# Altium schematic sheet RAM.SchDoc
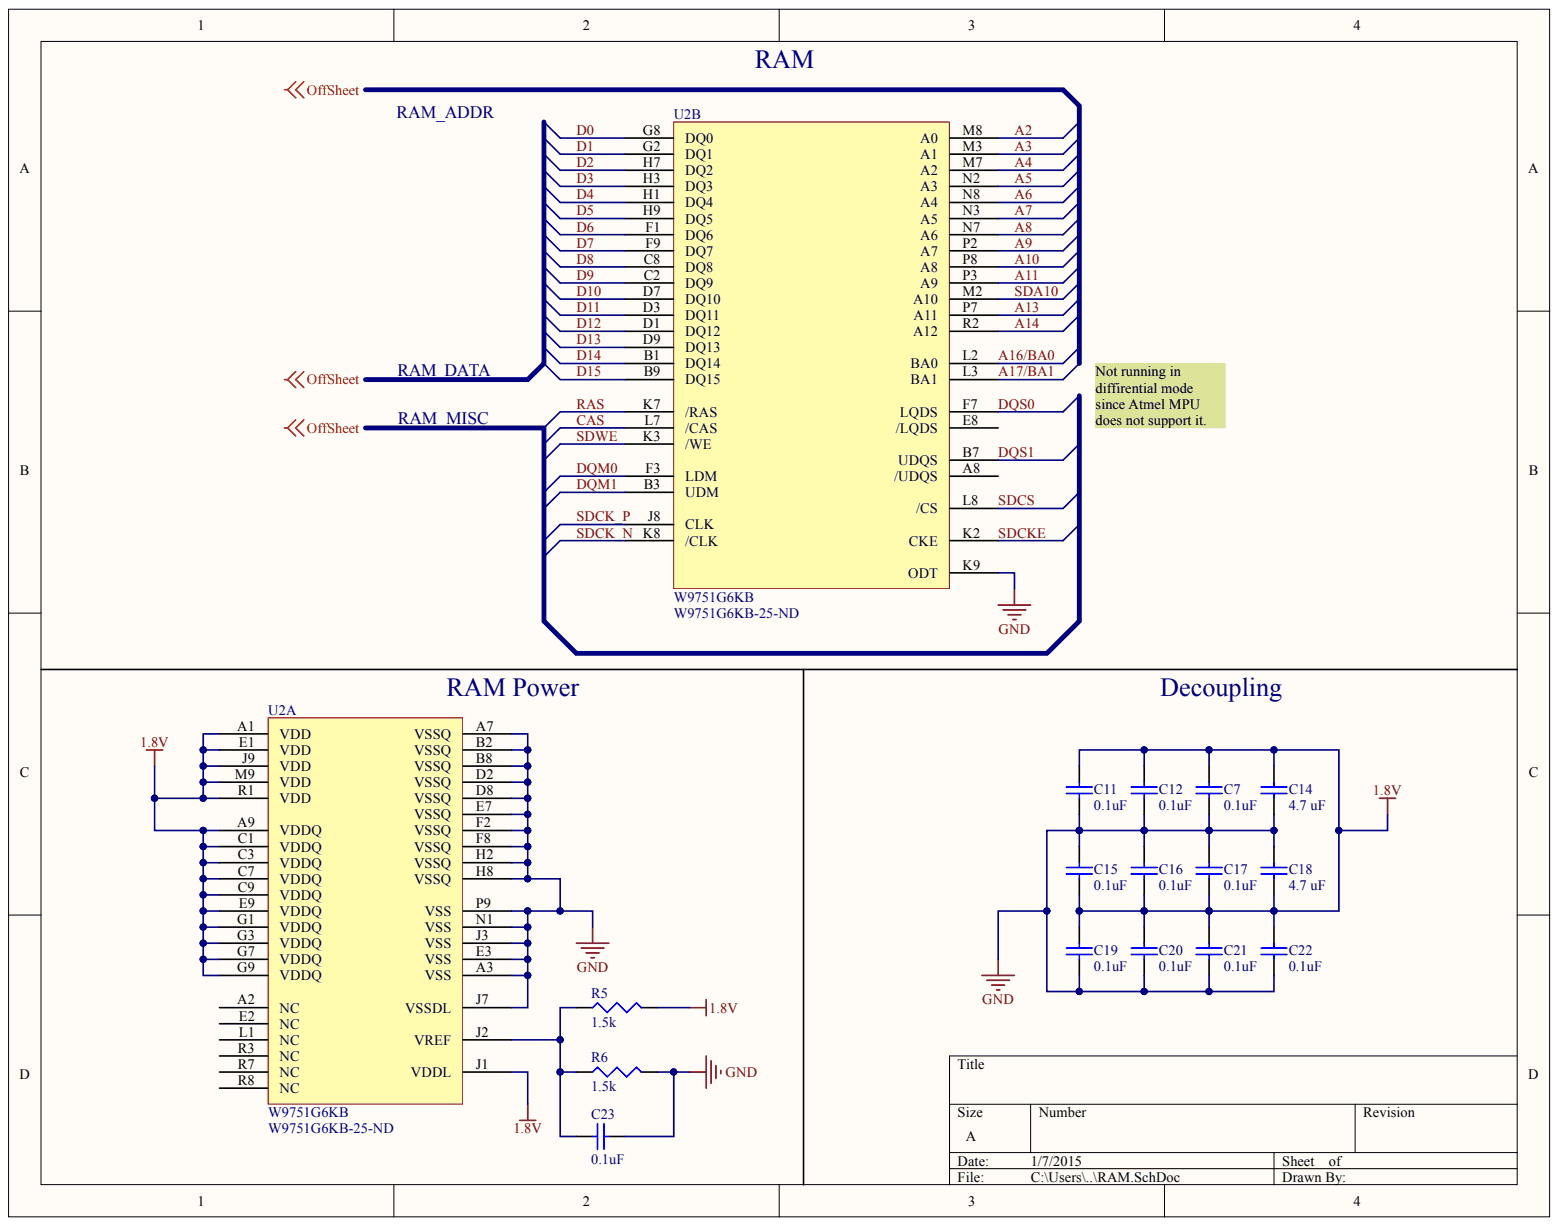
[diagram p0: RAM.SchDoc]

## Parts
C7  value 0.1uF  [0402]  (hidden comment: CL05B104KQ5NNNC)
C11  value 0.1uF  [0402]  (hidden comment: CL05B104KQ5NNNC)
C12  value 0.1uF  [0402]  (hidden comment: CL05B104KQ5NNNC)
C14  value 4.7 uF  [1608[0603]]  (hidden comment: CL10B475KQ8NQNC)
C15  value 0.1uF  [0402]  (hidden comment: CL05B104KQ5NNNC)
C16  value 0.1uF  [0402]  (hidden comment: CL05B104KQ5NNNC)
C17  value 0.1uF  [0402]  (hidden comment: CL05B104KQ5NNNC)
C18  value 4.7 uF  [1608[0603]]  (hidden comment: CL10B475KQ8NQNC)
C19  value 0.1uF  [0402]  (hidden comment: CL05B104KQ5NNNC)
C20  value 0.1uF  [0402]  (hidden comment: CL05B104KQ5NNNC)
C21  value 0.1uF  [0402]  (hidden comment: CL05B104KQ5NNNC)
C22  value 0.1uF  [0402]  (hidden comment: CL05B104KQ5NNNC)
C23  value 0.1uF  [0402]  (hidden comment: CL05B104KQ5NNNC)
R5  value 1.5k  [J1-0603]  (hidden comment: MCT06030C1501FP500)
R6  value 1.5k  [J1-0603]  (hidden comment: MCT06030C1501FP500)
U2  W9751G6KB  Supplier Part Number 1: W9751G6KB-25-ND  [BGA84C80P9X15_800X1250X120]  (parts A,B)

## Nets
1.8V: C7.1 C11.2 C12.1 C14.2 C15.1 C16.1 C17.2 C18.1 C19.2 C20.1 C21.2 C22.2 R5.2 U2.A1(VDD) U2.A9(VDDQ) U2.C1(VDDQ) U2.C3 U2.C7 U2.C9 U2.E1(VDD) U2.E9 U2.G1 U2.G3 U2.G7 U2.G9 U2.J1 U2.J9(VDD) U2.M9(VDD) U2.R1(VDD)
GND: C7.2 C11.1 C12.2 C14.1 C15.2 C16.2 C17.1 C18.2 C19.1 C20.2 C21.1 C22.1 C23.1 R6.2 U2.A3 U2.A7(VSSQ) U2.B2(VSSQ) U2.B8(VSSQ) U2.D2(VSSQ) U2.D8(VSSQ) U2.E3 U2.E7(VSSQ) U2.F2(VSSQ) U2.F8(VSSQ) U2.H2 U2.H8 U2.J3 U2.J7 U2.K9(ODT) U2.N1 U2.P9
A2: U2.M8(A0)
A3: U2.M3(A1)
A4: U2.M7(A2)
A5: U2.N2(A3)
A6: U2.N8(A4)
A7: U2.N3(A5)
A8: U2.N7(A6)
A9: U2.P2(A7)
A10: U2.P8(A8)
A11: U2.P3(A9)
A13: U2.P7(A11)
A14: U2.R2(A12)
A16/BA0: U2.L2(BA0)
A17/BA1: U2.L3(BA1)
CAS: U2.L7(/CAS)
D0: U2.G8(DQ0)
D1: U2.G2(DQ1)
D2: U2.H7(DQ2)
D3: U2.H3(DQ3)
D4: U2.H1(DQ4)
D5: U2.H9(DQ5)
D6: U2.F1(DQ6)
D7: U2.F9(DQ7)
D8: U2.C8(DQ8)
D9: U2.C2(DQ9)
D10: U2.D7(DQ10)
D11: U2.D3(DQ11)
D12: U2.D1(DQ12)
D13: U2.D9(DQ13)
D14: U2.B1(DQ14)
D15: U2.B9(DQ15)
DQM0: U2.F3(LDM)
DQM1: U2.B3(UDM)
DQS0: U2.F7(LQDS)
DQS1: U2.B7(UDQS)
RAS: U2.K7(/RAS)
SDA10: U2.M2(A10)
SDCK_N: U2.K8(/CLK)
SDCK_P: U2.J8(CLK)
SDCKE: U2.K2(CKE)
SDCS: U2.L8(/CS)
SDWE: U2.K3(/WE)
NetC23_2: C23.2 R5.1 R6.1 U2.J2
N00141:
N00142:
N00143:
OFFSHEET:

Not connected: U2 A2 A8(/UDQS) E2 E8(/LQDS) L1 R3 R7 R8

## Library data of the parts
C7 C11 C12 C15-C17 C19-C23 = CL05B104KQ5NNNC: Cap Ceramic 0.1uF 6.3V X7R 10% SMD 0402; Component Kind: Standard; LatestRevisionDate: 29-May-2009; LatestRevisionNote: IPC-7351 Footprint Added.; PackageDescription: Chip Capacitor; PackageReference: C1206; Published: 8-Jun-2000; Signal Integrity: Cap Semi; Simulation: CAP; Supplier 1: Arrow; Supplier 2: Digi-Key; Supplier Part Number 1: c0Ft5huTF1PnwzXLA59QPYxRs3E3aghew1LSAii7cAMZVywxIBNyoAQstvnyfZZ0; Supplier Part Number 2: 1276-1511-1-ND; Library Name: Linux_Board_SCHEM_LIB.SchLib; Publisher: Altium Limited
C14 C18 = CL10B475KQ8NQNC: CAP CER 4.7UF 6.3V 10% X7R 0603; Component Kind: Standard; LatestRevisionDate: 29-May-2009; LatestRevisionNote: IPC-7351 Footprint Added.; PackageDescription: Chip Capacitor; PackageReference: C1206; Published: 8-Jun-2000; Signal Integrity: Cap Semi; Simulation: CAP; Supplier 1: Digi-Key; Supplier Part Number 1: 1276-2087-1-ND; Library Name: Linux_Board_SCHEM_LIB.SchLib; Publisher: Altium Limited
R5 R6 = MCT06030C1501FP500: RES SMD 1.5K OHM 1% 1/8W 0603; Component Kind: Standard; LatestRevisionDate: 29-May-2009; LatestRevisionNote: IPC-7351 Footprint Added.; PackageDescription: Chip Resistor; PackageReference: J1-0603; Published: 8-Jun-2000; Signal Integrity: Res; Simulation: RESISTOR; Supplier 1: Digi-Key; Library Name: Linux_Board_SCHEM_LIB.SchLib; Publisher: Altium Limited
U2 = W9751G6KB: IC DDR2 SDRAM 512M 2.5NS 84WBGA; Component Kind: Standard; Supplier 1: Digi-Key; Library Name: Linux_Board_SCHEM_LIB.SchLib
